# Altium schematic sheet Sheet3.SchDoc(Sheet3)
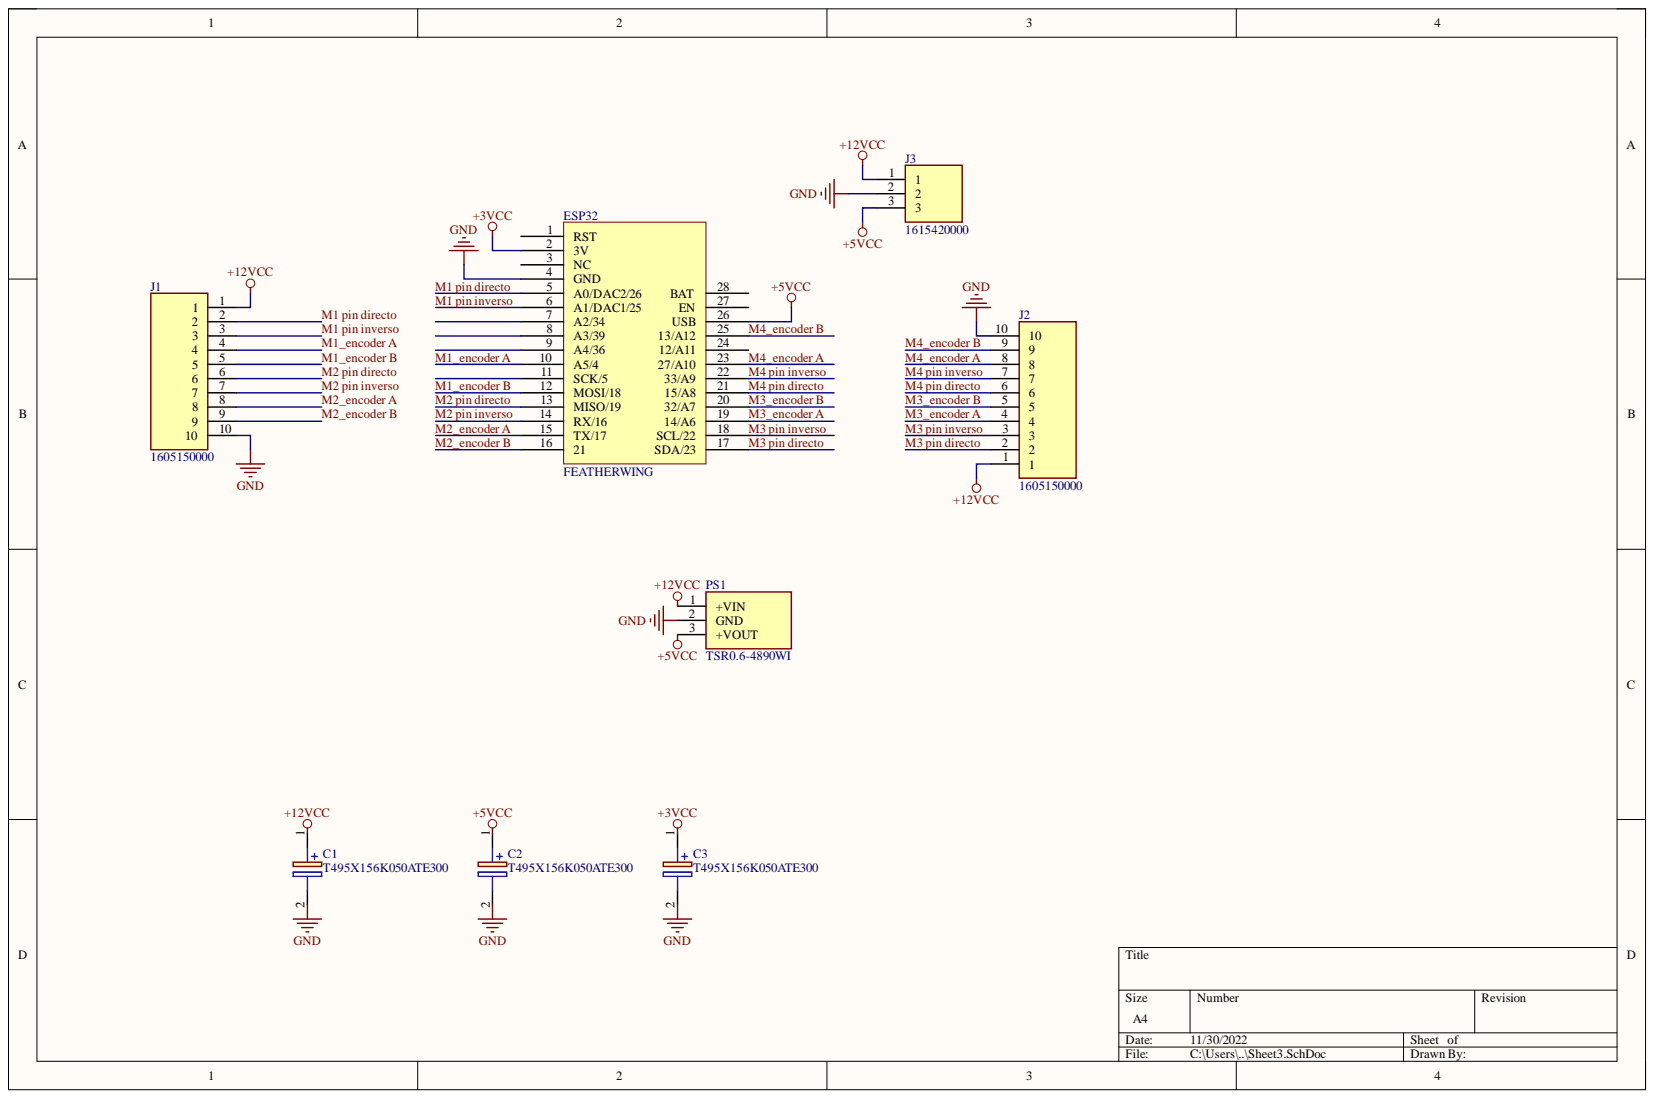
[diagram p0: Sheet3.SchDoc]

## Parts
C1  T495X156K050ATE300  [T495X]
C2  T495X156K050ATE300  [T495X]
C3  T495X156K050ATE300  [T495X]
ESP32  FEATHERWING  [FEATHERWING]
J1  1605150000  [1605150000]
J2  1605150000  [1605150000]
J3  1615420000  [1615420000]
PS1  TSR0.6-4890WI  [TSR064890WI]

## Nets
+3VCC: C3.1 ESP32.2(3V)
+5VCC: C2.1 ESP32.26(USB) J3.3 PS1.3
+12VCC: C1.1 J1.1 J2.1 J3.1 PS1.1
GND: C1.2 C2.2 C3.2 ESP32.4(GND) J1.10 J2.10 J3.2 PS1.2
M1 pin directo: ESP32.5(A0/DAC2/26) J1.2
M1 pin inverso: ESP32.6(A1/DAC1/25) J1.3
M1_encoder A: ESP32.10(A5/4) J1.4
M1_encoder B: ESP32.12(MOSI/18) J1.5
M2 pin directo: ESP32.13(MISO/19) J1.6
M2 pin inverso: ESP32.14(RX/16) J1.7
M2_encoder A: ESP32.15(TX/17) J1.8
M2_encoder B: ESP32.16 J1.9
M3 pin directo: ESP32.17(SDA/23) J2.2
M3 pin inverso: ESP32.18(SCL/22) J2.3
M3_encoder A: ESP32.19(14/A6) J2.4
M3_encoder B: ESP32.20(32/A7) J2.5
M4 pin directo: ESP32.21(15/A8) J2.6
M4 pin inverso: ESP32.22(33/A9) J2.7
M4_encoder A: ESP32.23(27/A10) J2.8
M4_encoder B: ESP32.25(13/A12) J2.9

Not connected: ESP32 1(RST) 3(NC) 7(A2/34) 8(A3/39) 9(A4/36) 11(SCK/5) 24(12/A11) 27(EN) 28(BAT)

## Library data of the parts
C1-C3 = T495X156K050ATE300: Capacitor Polarised; Manufacturer_Name: KEMET; Arrow Part Number: T495X156K050ATE300; Arrow Price/Stock: https://www.arrow.com/en/products/t495x156k050ate300/kemet-corporation?region=nac; Component Kind: Standard; Datasheet Link: https://content.kemet.com/datasheets/KEM_T2009_T495.pdf; Height: 4.3mm; Manufacturer_Part_Number: T495X156K050ATE300; Mouser Part Number: 80-T495X156K50ATE300; Mouser Price/Stock: https://www.mouser.co.uk/ProductDetail/KEMET/T495X156K050ATE300?qs=%252B8f20PJxj%252BPiIcleAWm0GQ%3D%3D
J1 J2 = 1605150000: Connector; Manufacturer_Name: Weidmuller; Component Kind: Standard; Datasheet Link: https://catalog.weidmueller.com/catalog/Start.do?ObjectID=1605150000&_ga=2.6498674.1743220027.1668519690-1396049382.1662723338&_gl=1*1pwgd2x*_ga*MTM5NjA0OTM4Mi4xNjYyNzIzMzM4*_ga_RQ78GF08L5*MTY2ODUxOTY4OS42LjEuMTY2ODUxOTc4OS4wLjAuMA..; Height: 7.5mm; Manufacturer_Part_Number: 1605150000
J3 = 1615420000: Connector; Manufacturer_Name: Weidmuller; Component Kind: Standard; Datasheet Link: https://catalog.weidmueller.com/catalog/Start.do?ObjectID=1615420000&_ga=2.32920696.771607185.1668484374-637528366.1664082173&_gl=1*12k5w1b*_ga*NjM3NTI4MzY2LjE2NjQwODIxNzM.*_ga_RQ78GF08L5*MTY2ODQ4NDM3NS45LjEuMTY2ODQ4NDM4Mi4wLjAuMA..; Height: 7.5mm; Manufacturer_Part_Number: 1615420000; Mouser Part Number: 470-1615420000; Mouser Price/Stock: https://www.mouser.co.uk/ProductDetail/Weidmuller/1615420000?qs=WJDKaGZ8K%252B4HpdOotYY2Sw%3D%3D
PS1 = TSR0.6-4890WI: Power Supply; Manufacturer_Name: Traco Power; Component Kind: Standard; Datasheet Link: https://www.tracopower.com/sites/default/files/products/datasheets/tsr0_6wi_datasheet.pdf; Height: 13.9mm; Manufacturer_Part_Number: TSR0.6-4890WI
ESP32 = FEATHERWING: Component Kind: Standard; Library Name: Schlib2.SchLib
